# Altium schematic sheet POWER.SchDoc(POWER)
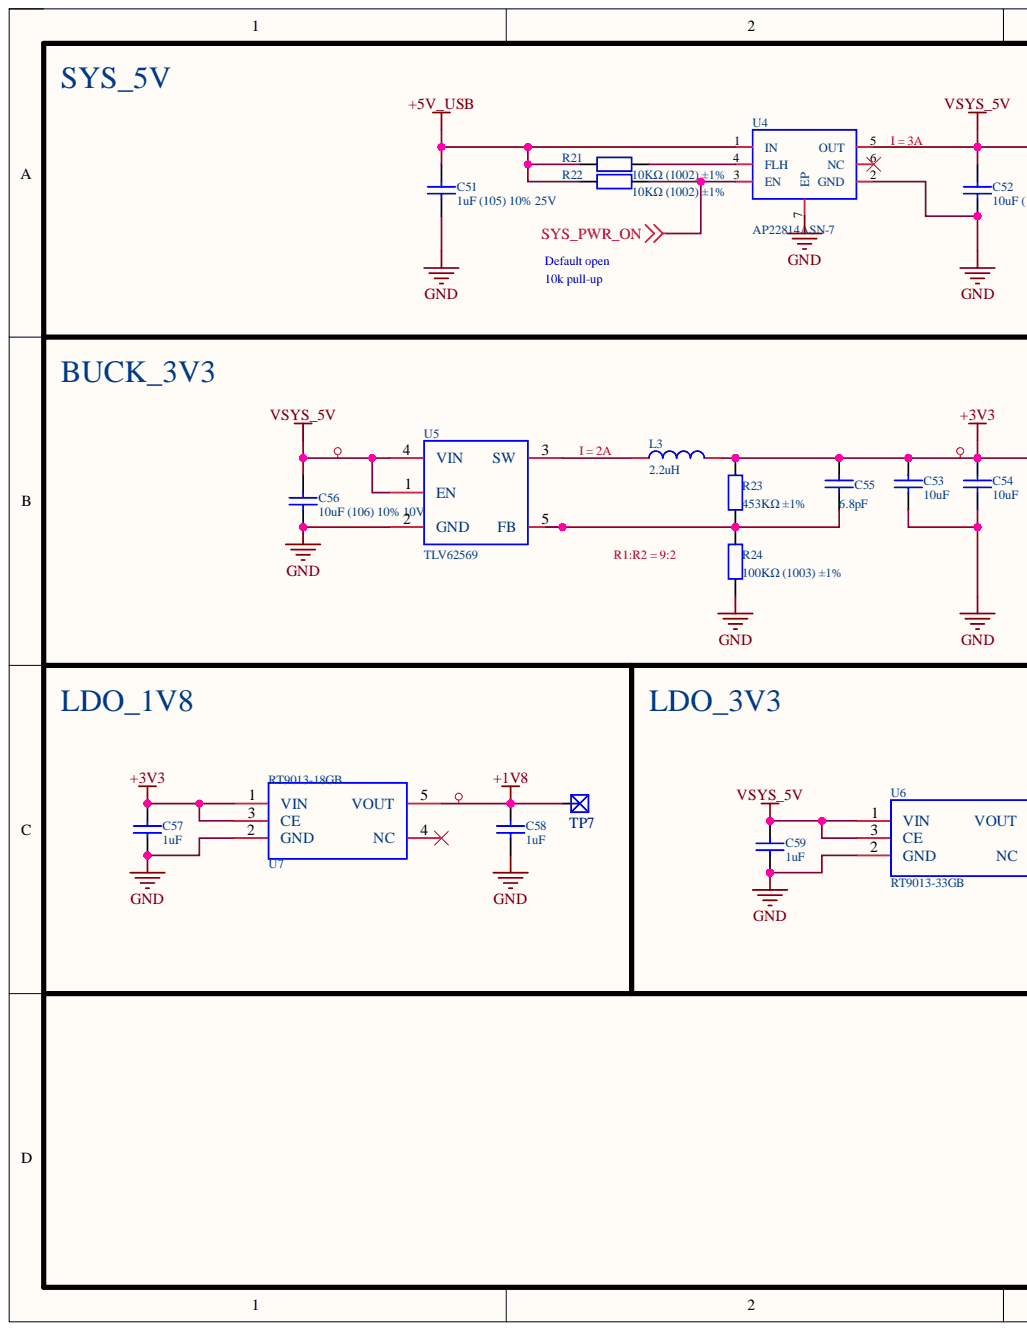
[diagram p0: POWER.SchDoc, left]
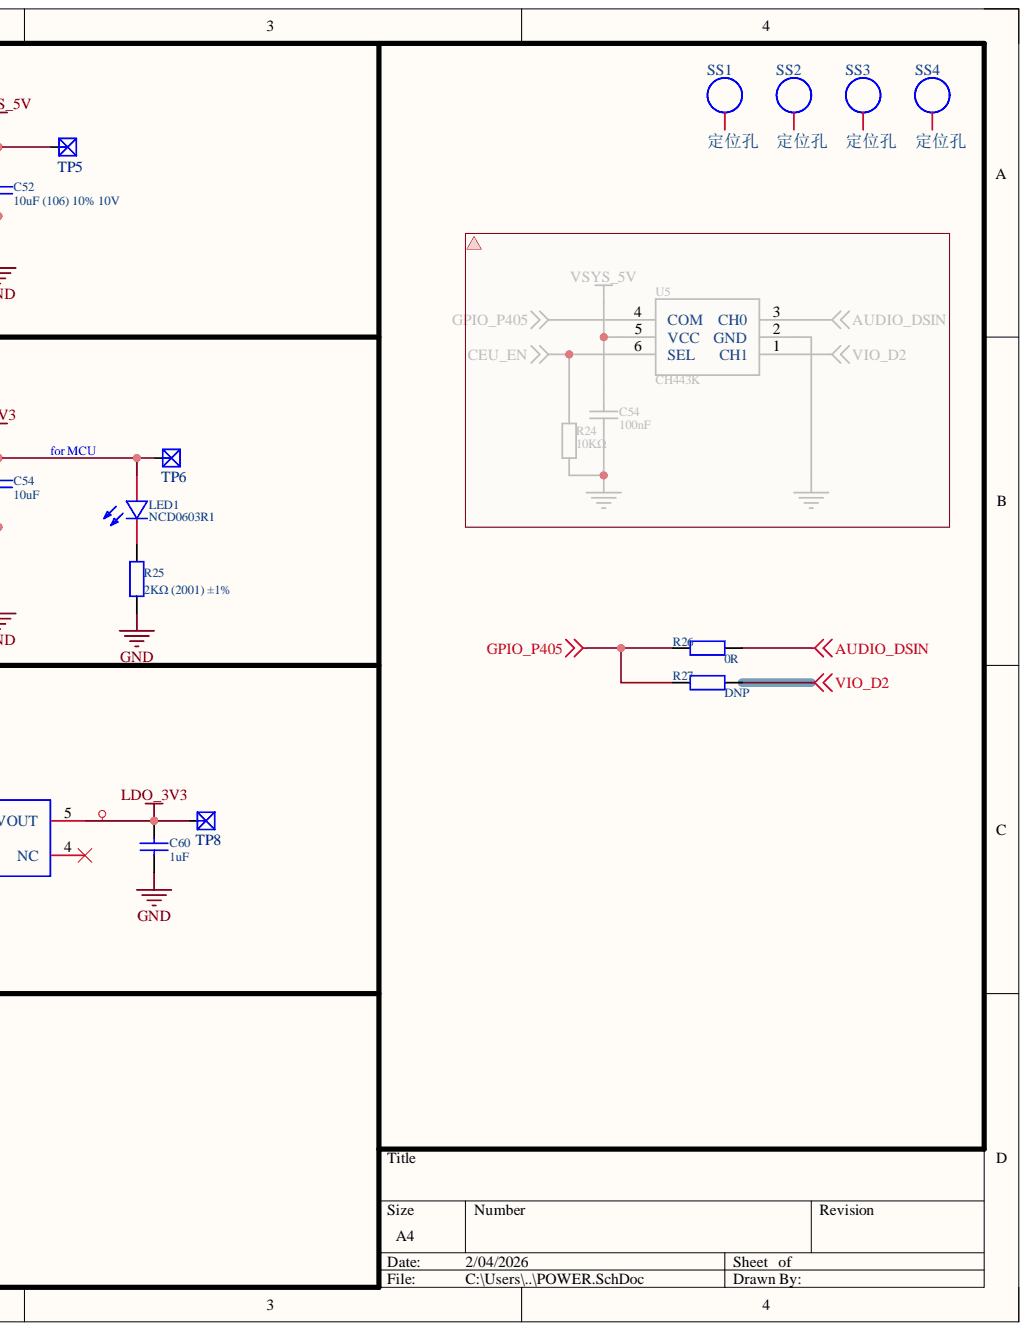
[diagram p1: POWER.SchDoc, right]

## Parts
C51  1uF (105) 10% 25V  [C0402]
C52  10uF (106) 10% 10V  [C0603]
C53  10uF  [C0603]
C54  10uF  [C0603]
C55  6.8pF  [C0402]
C56  10uF (106) 10% 10V  [C0603]
C57  1uF  [C0402]
C58  1uF  [C0402]
C59  1uF  [C0402]
C60  1uF  [C0402]
L3  2.2uH  [IND-SMD_L3.0-W3.0_FNR30XXS]
LED1  NCD0603R1  [LED0603-RD]
R21  10KΩ (1002) ±1%  [R0402]
R22  10KΩ (1002) ±1%  [R0402]
R23  453KΩ ±1%  [R0402]
R24  100KΩ (1003) ±1%  [R0402]
R25  2KΩ (2001) ±1%  [R0402]
R26  0R  [SOLDER-BRIDGE_R0402-0R]
R27  DNP  [SOLDER-BRIDGE_R0402-0R]
SS1  定位孔  [M3]  (no BOM)
SS2  定位孔  [M3]  (no BOM)
SS3  定位孔  [M3]  (no BOM)
SS4  定位孔  [M3]  (no BOM)
TP5  TEST POINT  [TP_M_0.5]  (value not drawn)
TP6  TEST POINT  [TP_M_0.5]  (value not drawn)
TP7  TEST POINT  [TP_M_0.5]  (value not drawn)
TP8  TEST POINT  [TP_M_0.5]  (value not drawn)
U4  AP22814ASN-7  [U-DFN2020-6_L2.0-W2.0-P0.65-BL-EP]
U5  TLV62569  [SOT-23-5]
U6  RT9013-33GB  [SOT-23-5_L3.0-W1.7-P0.95-LS2.8-BR]
U7  RT9013-18GB  [SOT-23-5_L3.0-W1.7-P0.95-LS2.8-BR]

## Nets
+1V8: C58.2 TP7.1 U7.5(VOUT)
+3V3: C53.1 C54.1 C55.2 C57.2 L3.2 LED1.2 R23.2 TP6.1 U7.1(VIN) U7.3(CE)
+5V_USB: C51.2 R21.1 R22.1 U4.1(IN)
AUDIO_DSIN: R26.2
GND: C51.1 C52.2 C53.2 C54.2 C56.2 C57.1 C58.1 C59.1 C60.1 R24.1 R25.1 U4.2(GND) U4.7(EP) U5.2(GND) U6.2(GND) U7.2(GND)
GPIO_P405: R26.1 R27.1
LDO_3V3: C60.2 TP8.1 U6.5(VOUT)
SYS_PWR_ON: R22.2 U4.3(EN)
VIO_D2: R27.2
VSYS_5V: C52.1 C56.1 C59.2 TP5.1 U4.5(OUT) U5.1(EN) U5.4(VIN) U6.1(VIN) U6.3(CE)
NetC55_1: C55.1 R23.1 R24.2 U5.5(FB)
NetL3_1: L3.1 U5.3(SW)
NetLED1_1: LED1.1 R25.2
NetR21_2: R21.2 U4.4(FLH)

Not connected: SS1 0; SS2 0; SS3 0; SS4 0; U4 6(NC); U6 4(NC); U7 4(NC)

## Library data of the parts
C51 C57-C60 = 0402 1uF (105) 10% 25V: 贴片电容; Component Kind: Standard; ComponentLink1Description: 供应商链接; ComponentLink1URL: http://www.szlcsc.com/product/details_53938.html; Library Name: RA8P1.SCHLIB; Package: 0402; Supplier: LC; SuppliersPartNumber: C52923
C52-C54 C56 = 0603 10uF (106) 10% 10V: 贴片电容; Component Kind: Standard; ComponentLink1Description: 供应商链接; ComponentLink1URL: http://www.szlcsc.com/product/details_20411.html; Library Name: RA8P1.SCHLIB; Package: 0603; Supplier: LC; SuppliersPartNumber: C19702
C55 = 0402 6.8pF (6R8) 50V: 贴片电容; Component Kind: Standard; ComponentLink1Description: 供应商链接; ComponentLink1URL: https://item.szlcsc.com/90076.html?fromZone=s_s__%25226.8pF%2520(6R8)%25200.25pF%252050V%2522&spm=sc.gbn.xh1.zy.n&lcsc_vid=RwBfVQZSEwJZV1NUEVEPX1cHQQNZXgUCFFhXBVwEFFcxVlNTR1lfUVZSQ1NaVzsOAxUeFF5JWBIBSRccGwIdBEoFGAxBAAgJFQACSQwSGg0%3D; Library Name: ra8p1_v0.2.SCHLIB; Package: 0402; Supplier: LC; SuppliersPartNumber: C88896
L3 = FHD3012S-2R2MT: 2.35A ,90mΩ; Manufacturer: cjiang(长江微电); Add into BOM: yes; DC Resistance (DCR): 62mΩ; Device: FTC252010S2R2MBCA; Rated Current: 2.4A; Saturation Current (Isat): 3.3A; Tolerance: ±20%; Type: 一体成型电感; Component Kind: Standard; Convert to PCB: yes; Datasheet: https://atta.szlcsc.com/upload/public/pdf/source/20230602/8FFEDA9C0247A92A0D4B67554BFE4091.pdf; JLCPCB Part Class: Extended Part; LCSC Part Name: 2.2uH ±20% 3.3A; Library Name: RA8P1.SCHLIB; Manufacturer Part: FTC252010S2R2MBCA; Supplier: LCSC; Supplier Footprint: SMD,2x2.5mm; Supplier Part: C5832358; Symbol: FTC252010S2R2MBCA; Value: 2.2uH
LED1 = LED0603RED: RED,0603; Manufacturer: 国星光电; Add into BOM: yes; Device: NCD0603R1_C84263; Diode Configuration: 独立二极管; Forward Current: 25mA; Illumination Color: 红色; Luminous Intensity: 80mcd~220mcd; Power(Watts): 65mW; Symbol: FC-DA1608HRK-620D; Viewing Angle: 130°; Voltage - Forward(Vf): 1.6V~2.6V; Wavelength - Dominant: 615nm~630nm; Color Temperature: -; Component Kind: Standard; Convert to PCB: yes; Datasheet: https://atta.szlcsc.com/upload/public/pdf/source/20200820/C84263_DABC6A75E58F576973B407FDBA283D60.pdf; JLCPCB Part Class: Extended Part; Lamp Holder Type: -; LCSC Part Name: NCD0603R1; Lens Color: -; Library Name: ra8p1_v0.1.SCHLIB; Manufacturer Part: NCD0603R1; Mounting Sytle: -; Operating Temperature: -30℃~+85℃; PCB3D: LED0603-RD_RED; Peak Wavelength: -; Supplier: LCSC; Supplier Footprint: 0603; Supplier Part: C84263
R21 R22 = 0402 10KΩ (1002) 1%: 贴片电阻，10K; Component Kind: Standard; ComponentLink1Description: 供应商链接; ComponentLink1URL: https://item.szlcsc.com/26487.html?fromZone=l_b__%2522brand%2522&spm=sc.bid.xh1.zy.n&lcsc_vid=FANcVFBQFQMNX1cFFAdZVVZXEgdeAgdWFlQNUVAHT1ExVlNSRFhXVlJQQFlYVzsOAxUeFF5JWA0HFBBIBBsCBBcFWQIBCksEBQAAA0tfTk8GEwkK; Library Name: RA8P1.SCHLIB; Package: 0402; Supplier: LC; SuppliersPartNumber: C25744
R23 = 0402 470KΩ (4703) 1%: 贴片电阻; Component Kind: Standard; ComponentLink1Description: 供应商链接; ComponentLink1URL: http://www.szlcsc.com/product/details_26533.html; Library Name: RES.SchLib; Package: 0402; Supplier: LC; SuppliersPartNumber: C25790
R24 = 0402 100KΩ (1003) 1%: 贴片电阻; Component Kind: Standard; ComponentLink1Description: 供应商链接; ComponentLink1URL: http://www.szlcsc.com/product/details_26484.html; Library Name: RES.SchLib; Package: 0402; Supplier: LC; SuppliersPartNumber: C25741
R25 = 0402 2KΩ (2001) 1%: 贴片电阻; Component Kind: Standard; ComponentLink1Description: 供应商链接; ComponentLink1URL: http://www.szlcsc.com/product/details_4516.html; Library Name: RES.SchLib; Package: 0402; Supplier: LC; SuppliersPartNumber: C4109
R26 R27 = 0402 0Ω: 贴片电阻,跳线电阻; Component Kind: Standard; ComponentLink1Description: 供应商链接; ComponentLink1URL: http://www.szlcsc.com/product/details_17853.html; Library Name: RA8P1.SCHLIB; Package: 0402; Supplier: LC; SuppliersPartNumber: C17168
TP5-TP8 = TP: Component Kind: Standard; Library Name: RA8P1.SCHLIB
U5 = TLV62569: TLV62569 2A, 5.5V, 1.5MHz STEP-DOWN CONVERTER IN A TSOT23-5; Component Kind: Standard; Library Name: ra8p1_v0.2.SCHLIB
U6 = RT9013-33GB: LDO; Manufacturer: TECH PUBLIC(台舟); Add into BOM: yes; Number of Outputs: 1; Output Configuration: 正极; Output Current: 500mA; Output Type: 固定; Output Voltage: 3.3; Power Supply Rejection Ratio (PSRR): 75dB@(1kHz); Voltage - Supply: 7V; Component Kind: Standard; Convert to PCB: yes; Datasheet: https://item.szlcsc.com/20526335.html?fromZone=s_s__%2522RT9013-33GB%2520SOT-23-5%2522&spm=sc.gbn.xh2.zy.n___sc.cidn.hd.ss&lcsc_vid=RFBdUgFWTgRXA1VWFlVXVlZfEVRbAgVXRAcPX1ZSE1YxVlNQQVZfXlJSQFdcXjsOAxUeFF5JWBIBSRccGwIdBEoFGAxBAAgJFQACSQwSGg0%3D; Device: RT9013-33GB; JLCPCB Part Class: Extended Part; LCSC Part Name: RT9013-33GB; Library Name: RA8P1.SCHLIB; Manufacturer Part: RT9013-33GB; Operating Temperature: -40℃~+85℃@(Ta); PCB3D: SOT-23-5_L2.9-W1.6-H1.1-LS2.8-P0.95; Supplier: LCSC; Supplier Footprint: SOT-23-5; Supplier Part: C19268157; Symbol: RT9013-33GB
U7 = RT9013-18GB_C22435116: LDO; Manufacturer: TECH PUBLIC(台舟); Add into BOM: yes; Number of Outputs: 1; Output Configuration: 正极; Output Current: 500mA; Output Type: 固定; Output Voltage: 1.8V; Power Supply Rejection Ratio (PSRR): 75dB@(1kHz); Voltage - Supply: 7V; Component Kind: Standard; Convert to PCB: yes; Datasheet: https://atta.szlcsc.com/upload/public/pdf/source/20240521/96856EEC3938E9CD57929E5A61E5793B.pdf; Device: RT9013-18GB_C22435116; JLCPCB Part Class: Extended Part; LCSC Part Name: RT9013-18GB; Library Name: ra8p1_v0.1.SCHLIB; Manufacturer Part: RT9013-18GB; Operating Temperature: -40℃~+85℃@(Ta); PCB3D: SOT-23-5_L2.9-W1.6-H1.1-LS2.8-P0.95; Supplier: LCSC; Supplier Footprint: SOT-23-5; Supplier Part: C22435116; Symbol: RT9013-18GB_C22435116
SS1-SS4 = 定位孔: Description: 定位孔; Library Name: RA8P1.SCHLIB
U4 = AP22814ASN-7: Component Kind: Standard; Library Name: RA8P1.SCHLIB; Symbol: AP22814ASN-7
